# Altium schematic sheet Voilier_telecom.SchDoc
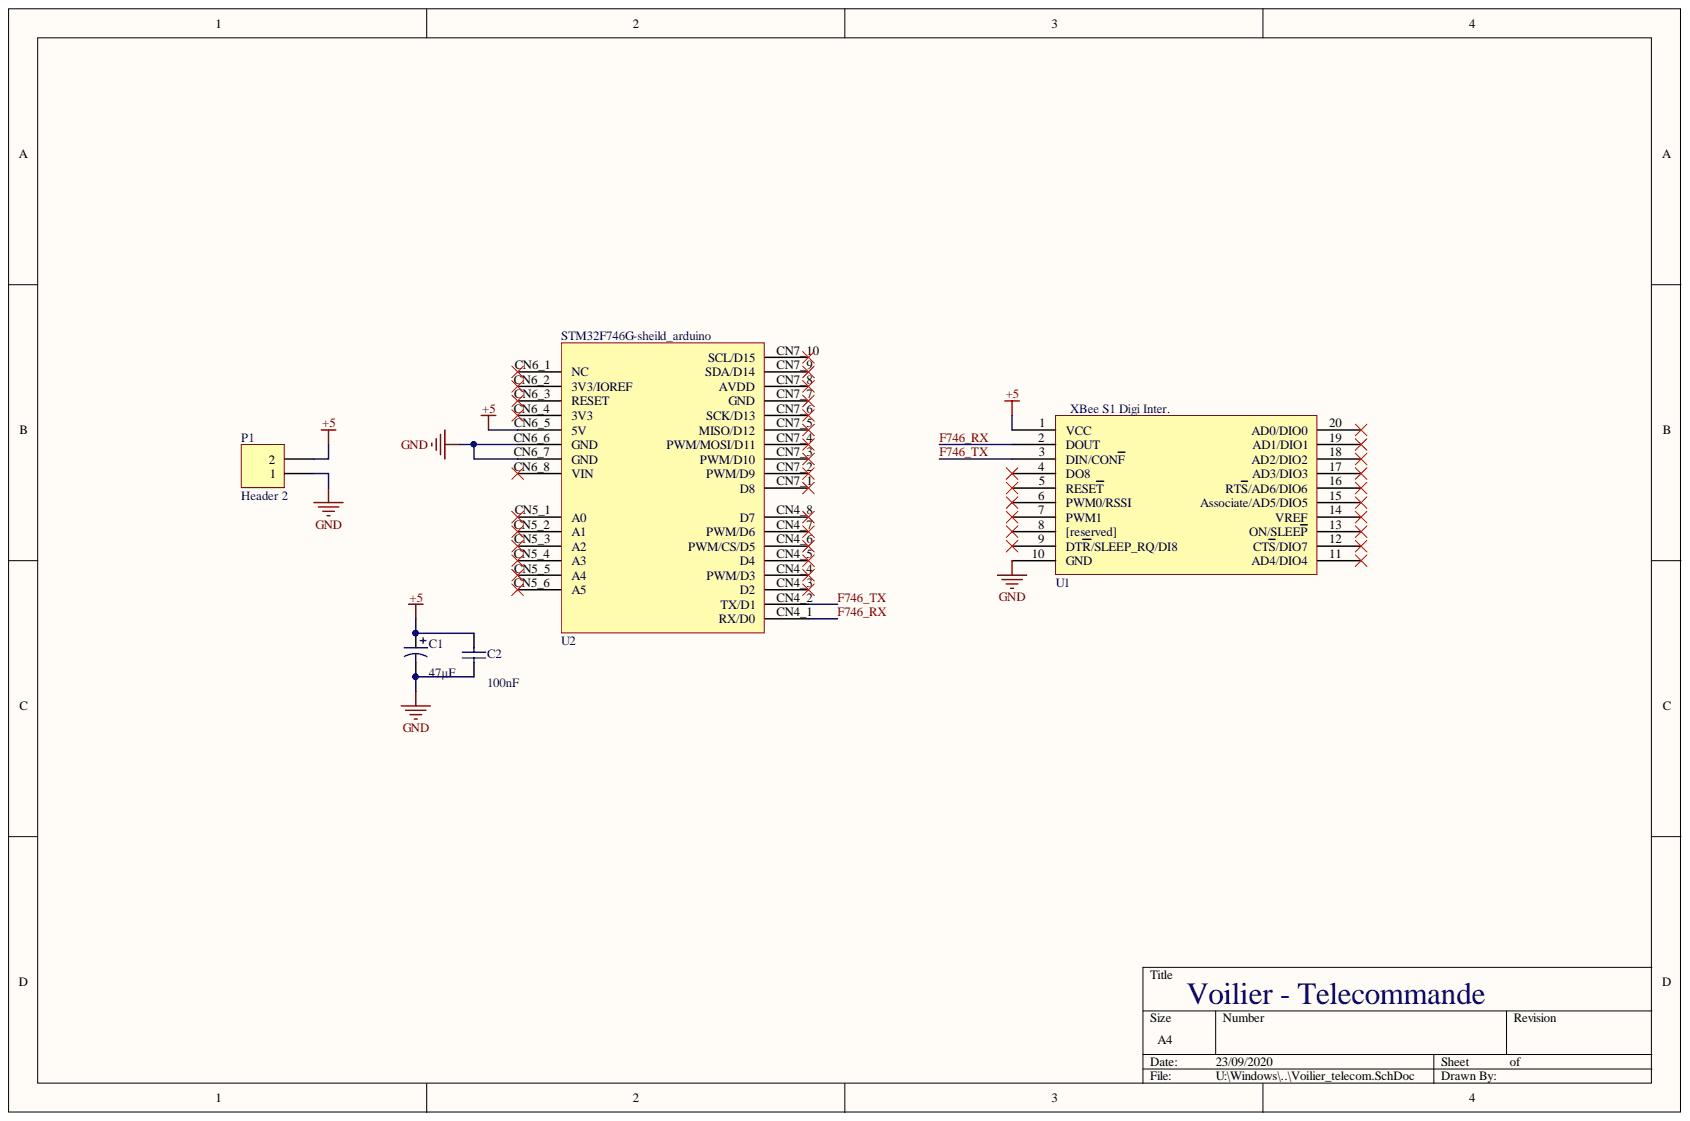
[diagram p0: Voilier_telecom.SchDoc]

## Parts
C1  value 47µF  [CONDO_POL]  (hidden comment: Cap Pol1)
C2  value 100nF  [condo]  (hidden comment: Cap)
P1  Header 2  [HDR1X2]
U1  digi_xbee_s1  [XBEE]  (value not drawn)
U2  STM32F746G-sheild_arduino  [sheild_arduino]

## Nets
+5: C1.1 C2.2 P1.2 U1.1(VCC) U2.CN6_5(5V)
GND: C1.2 C2.1 P1.1 U1.10(GND) U2.CN6_6(GND) U2.CN6_7(GND)
F746_RX: U1.2(DOUT) U2.CN4_1(RX/D0)
F746_TX: U1.3(DIN/CONF) U2.CN4_2(TX/D1)

Not connected: U1 4(DO8) 5(RESET) 6(PWM0/RSSI) 7(PWM1) 8([reserved]) 9(DTR/SLEEP_RQ/DI8) 11(AD4/DIO4) 12(CTS/DIO7) 13(ON/SLEEP) 14(VREF) 15(Associate/AD5/DIO5) 16(RTS/AD6/DIO6) 17(AD3/DIO3) 18(AD2/DIO2) 19(AD1/DIO1) 20(AD0/DIO0); U2 CN4_3(D2) CN4_4(PWM/D3) CN4_5(D4) CN4_6(PWM/CS/D5) CN4_7(PWM/D6) CN4_8(D7) CN5_1(A0) CN5_2(A1) CN5_3(A2) CN5_4(A3) CN5_5(A4) CN5_6(A5) CN6_1(NC) CN6_2(3V3/IOREF) CN6_3(RESET) CN6_4(3V3) CN6_8(VIN) CN7_1(D8) CN7_2(PWM/D9) CN7_3(PWM/D10) CN7_4(PWM/MOSI/D11) CN7_5(MISO/D12) CN7_6(SCK/D13) CN7_7(GND) CN7_8(AVDD) CN7_9(SDA/D14) CN7_10(SCL/D15)

## Library data of the parts
C1 = Cap Pol1: Polarized Capacitor (Radial); Component Kind: Standard; LatestRevisionDate: 17-Jul-2002; LatestRevisionNote: Re-released for DXP Platform.; Library Name: Miscellaneous Devices.IntLib; PackageDescription: Polarized Capacitor (Radial); 2 Leads; PackageReference: RB7.6-15; Published: 8-Jun-2000; Signal Integrity: Cap Pol; Simulation: CAP; Publisher: Altium Limited
C2 = Cap: Capacitor; Component Kind: Standard; LatestRevisionDate: 17-Jul-2002; LatestRevisionNote: Re-released for DXP Platform.; Library Name: Miscellaneous Devices.IntLib; PackageDescription: Radial Cap, Thru-Hole; 2 Leads; 0.3 in Pin Spacing; PackageReference: RAD-0.3; Published: 8-Jun-2000; Signal Integrity: Cap; Simulation: CAP; Publisher: Altium Limited
P1 = Header 2: Header, 2-Pin; Component Kind: Standard; LatestRevisionDate: 17-Jul-2002; LatestRevisionNote: Re-released for DXP Platform.; Library Name: Miscellaneous Connectors.IntLib; Signal Integrity: Connector; Publisher: Altium Limited
U2 = sheild_arduino: Component Kind: Standard; Library Name: alitum_lib.SchLib
U1 = digi_xbee_s1: Component Kind: Standard; Library Name: alitum_lib.SchLib
